# Altium schematic sheet 07 USB2.0_HOST.SchDoc
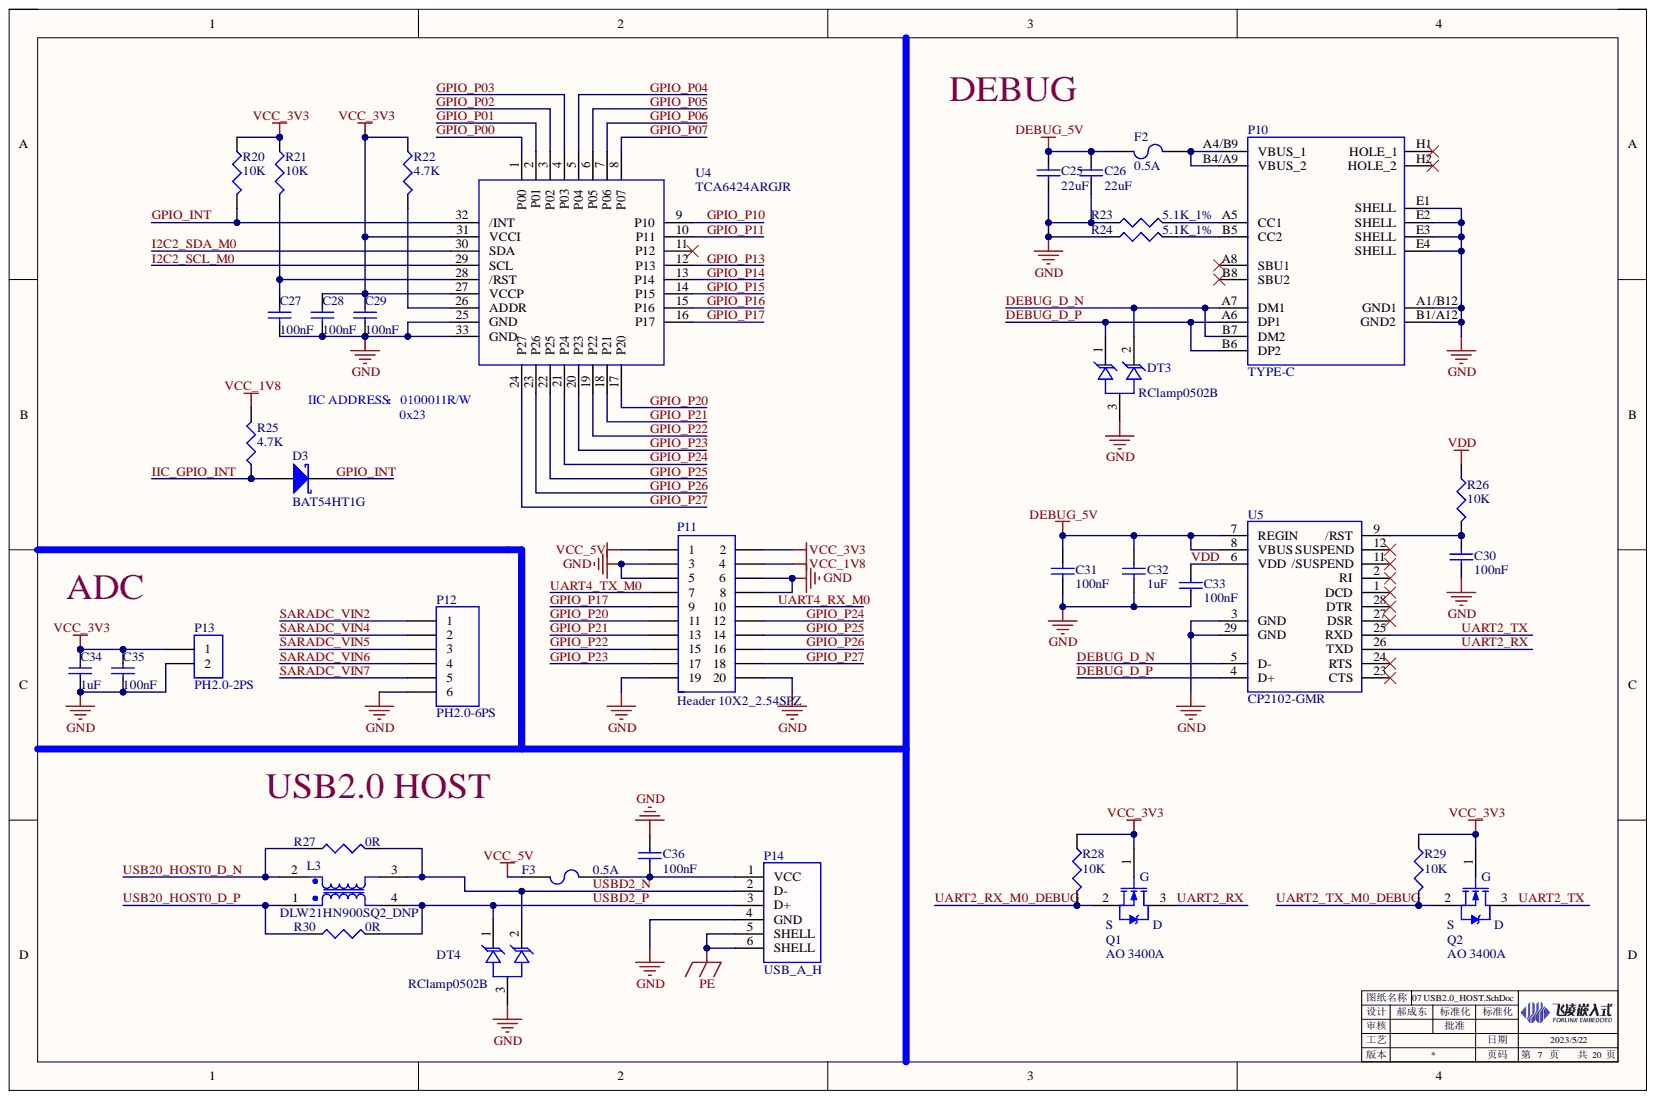
[diagram p0: 07 USB2.0_HOST.SchDoc]

## Parts
C25  22uF  [0603C]
C26  22uF  [0603C]
C27  100nF  [0402C]
C28  100nF  [0402C]
C29  100nF  [0402C]
C30  100nF  [0402C]
C31  100nF  [0402C]
C32  1uF  [0402C]
C33  100nF  [0402C]
C34  1uF  [0402C]
C35  100nF  [0402C]
C36  100nF  [0402C]
D3  BAT54HT1G  [SOD_323]
DT3  RClamp0502B  [SOT_523]
DT4  RClamp0502B  [SOT_523]
F2  0.5A  [1210F]
F3  0.5A  [1210F]
L3  DLW21HN900SQ2_DNP  [L_CHK_2012]
P10  TYPE-C  [USB_TYPE-C_S]
P11  Header 10X2_2.54SPZ  [HEADER2*10_2.54_SPZ]
P12  PH2.0-6PS  [PH2.0_6P_V]
P13  PH2.0-2PS  [PH2.0_2P_V]
P14  USB_A_H  [USB_A_F_H]
Q1  AO 3400A  [SOT_233_W]
Q2  AO 3400A  [SOT_233_W]
R20  10K  [0402R]
R21  10K  [0402R]
R22  4.7K  [0402R]
R23  5.1K_1%  [0402R]
R24  5.1K_1%  [0402R]
R25  4.7K  [0402R]
R26  10K  [0402R]
R27  0R  [0402R]
R28  10K  [0402R]
R29  10K  [0402R]
R30  0R  [0402R]
U4  TCA6424ARGJR  [QFN32P_0.5BSC_5*5_H]
U5  CP2102-GMR  [QFN28P_0.5BSC_5*5_H]

## Nets
DEBUG_5V: C25.2 C26.2 C31.2 C32.2 F2.2 U5.7(REGIN) U5.8(VBUS)
GND: C25.1 C26.1 C27.1 C28.1 C29.1 C30.1 C31.1 C32.1 C33.1 C34.1 C35.1 C36.2 DT3.3 DT4.3 P10.A1/B12(GND1) P10.B1/A12(GND2) P10.E1(SHELL) P10.E2(SHELL) P10.E3(SHELL) P10.E4(SHELL) P11.3 P11.5 P11.6 P11.8 P11.19 P11.20 P12.6 P13.2 P14.4(GND) R23.1 R24.1 U4.25(GND) U4.33(GND) U5.3(GND) U5.29(GND)
PE: P14.5(SHELL) P14.6(SHELL)
VCC_1V8: P11.4 R25.2
VCC_3V3: C28.2 C29.2 C34.2 C35.2 P11.2 P13.1 Q1.1 Q2.1 R20.1 R21.1 R22.1 R28.1 R29.1 U4.27(VCCP) U4.31(VCCI)
VCC_5V: F3.2 P11.1
DEBUG_D_N: DT3.2 P10.A7(DM1) P10.B7(DM2) U5.5(D-)
DEBUG_D_P: DT3.1 P10.A6(DP1) P10.B6(DP2) U5.4(D+)
GPIO_INT: D3.2 R20.2 U4.32(/INT)
GPIO_P00: U4.1(P00)
GPIO_P01: U4.2(P01)
GPIO_P02: U4.3(P02)
GPIO_P03: U4.4(P03)
GPIO_P04: U4.5(P04)
GPIO_P05: U4.6(P05)
GPIO_P06: U4.7(P06)
GPIO_P07: U4.8(P07)
GPIO_P10: U4.9(P10)
GPIO_P11: U4.10(P11)
GPIO_P13: U4.12(P13)
GPIO_P14: U4.13(P14)
GPIO_P15: U4.14(P15)
GPIO_P16: U4.15(P16)
GPIO_P17: P11.9 U4.16(P17)
GPIO_P20: P11.11 U4.17(P20)
GPIO_P21: P11.13 U4.18(P21)
GPIO_P22: P11.15 U4.19(P22)
GPIO_P23: P11.17 U4.20(P23)
GPIO_P24: P11.12 U4.21(P24)
GPIO_P25: P11.14 U4.22(P25)
GPIO_P26: P11.16 U4.23(P26)
GPIO_P27: P11.18 U4.24(P27)
I2C2_SCL_M0: U4.29(SCL)
I2C2_SDA_M0: U4.30(SDA)
IIC_GPIO_INT: D3.1 R25.1
SARADC_VIN2: P12.1
SARADC_VIN4: P12.2
SARADC_VIN5: P12.3
SARADC_VIN6: P12.4
SARADC_VIN7: P12.5
UART2_RX: Q1.3 U5.26(TXD)
UART2_RX_M0_DEBUG: Q1.2 R28.2
UART2_TX: Q2.3 U5.25(RXD)
UART2_TX_M0_DEBUG: Q2.2 R29.2
UART4_RX_M0: P11.10
UART4_TX_M0: P11.7
USB20_HOST0_D_N: L3.2 R27.1
USB20_HOST0_D_P: L3.1 R30.1
USBD2_N: DT4.2 L3.3 P14.2(D-) R27.2
USBD2_P: DT4.1 L3.4 P14.3(D+) R30.2
VDD: C33.2 R26.1 U5.6(VDD)
NetC27_2: C27.2 R21.2 U4.28(/RST)
NetC30_2: C30.2 R26.2 U5.9(/RST)
NetC36_1: C36.1 F3.1 P14.1(VCC)
NetF2_1: F2.1 P10.A4/B9(VBUS_1) P10.B4/A9(VBUS_2)
NetP10_A5: P10.A5(CC1) R23.2
NetP10_B5: P10.B5(CC2) R24.2
NetR22_2: R22.2 U4.26(ADDR)

Not connected: P10 A8(SBU1) B8(SBU2) H1(HOLE_1) H2(HOLE_2); U4 11(P12); U5 1(DCD) 2(RI) 11(/SUSPEND) 12(SUSPEND) 23(CTS) 24(RTS) 27(DSR) 28(DTR)

## Library data of the parts
C25 C26 = 1110203040: Database Table Name: VALID$; 主计量单位名称: 个; 存货名称: 贴装叠层陶瓷电容; 安全库存: 678357; 序号: 675; 旧编码: 010202048; 旧规格型号: 0603 22uF 6.3V ±20％ X5R; 规格型号: 0603,22uF,6.3V,±20％,X5R; Component Kind: Standard; LatestRevisionDate: 17-Jul-2002; LatestRevisionNote: Re-released for DXP Platform.; Library Name: Database_AD Library.DbLib; PackageDescription: Radial Cap, Thru-Hole; 2 Leads; 0.3 in Pin Spacing; PackageReference: RAD-0.3; Published: 8-Jun-2000; Signal Integrity: Cap; Simulation: CAP; Value: 22uF; 存货编码: 1110203040; Publisher: Altium Limited
C27-C29 C35 C36 = 1110202039: Database Table Name: VALID$; 存货名称: 贴装叠层陶瓷电容; 序号: 626; 规格型号: 0402,100nF,25V,±10％,X5R; Component Kind: Standard; LatestRevisionDate: 17-Jul-2002; LatestRevisionNote: Re-released for DXP Platform.; Library Name: Database_AD Library.DbLib; PackageDescription: Radial Cap, Thru-Hole; 2 Leads; 0.3 in Pin Spacing; PackageReference: RAD-0.3; Published: 8-Jun-2000; Signal Integrity: Cap; Simulation: CAP; Value: 100nF; 存货编码: 1110202039; Publisher: Altium Limited
C30 C31 C33 = 1110202001: Database Table Name: VALID$; 主计量单位名称: 个; 存货名称: 贴装叠层陶瓷电容; 安全库存: 2580445; 序号: 570; 旧编码: 010201001; 旧规格型号: 0402 100nF 16V ±10％ X7R; 规格型号: 0402,100nF,16V,±10％,X7R; Component Kind: Standard; LatestRevisionDate: 17-Jul-2002; LatestRevisionNote: Re-released for DXP Platform.; Library Name: Database_AD Library.DbLib; PackageDescription: Radial Cap, Thru-Hole; 2 Leads; 0.3 in Pin Spacing; PackageReference: RAD-0.3; Published: 8-Jun-2000; Signal Integrity: Cap; Simulation: CAP; Value: 100nF; 存货编码: 1110202001; Publisher: Altium Limited
C32 = 1110202002: Database Table Name: VALID$; 主计量单位名称: 个; 存货名称: 贴装叠层陶瓷电容; 安全库存: 499709; 序号: 571; 旧编码: 010201002; 旧规格型号: 0402 1uF 10V ±10％ X5R; 规格型号: 0402,1uF,10V,±10％,X5R; Component Kind: Standard; LatestRevisionDate: 17-Jul-2002; LatestRevisionNote: Re-released for DXP Platform.; Library Name: Database_AD Library.DbLib; PackageDescription: Radial Cap, Thru-Hole; 2 Leads; 0.3 in Pin Spacing; PackageReference: RAD-0.3; Published: 8-Jun-2000; Signal Integrity: Cap; Simulation: CAP; Value: 1uF; 存货编码: 1110202002; Publisher: Altium Limited
C34 = 1110202021: Database Table Name: VALID$; 存货名称: 贴装叠层陶瓷电容; 序号: 600; 规格型号: 0402,1uF,16V,±10％,X5R; Component Kind: Standard; LatestRevisionDate: 17-Jul-2002; LatestRevisionNote: Re-released for DXP Platform.; Library Name: Database_AD Library.DbLib; PackageDescription: Radial Cap, Thru-Hole; 2 Leads; 0.3 in Pin Spacing; PackageReference: RAD-0.3; Published: 8-Jun-2000; Signal Integrity: Cap; Simulation: CAP; Value: 1uF; 存货编码: 1110202021; Publisher: Altium Limited
D3 = 1110501028: Database Table Name: VALID$; 存货名称: 肖特基二极管; 序号: 2525; 规格型号: BAT54HT1G,SOD323; Code_JEDEC: DO-214-AA; Component Kind: Standard; LatestRevisionDate: 17-Jul-2002; LatestRevisionNote: Re-released for DXP Platform.; Library Name: Database_AD Library.DbLib; PackageDescription: DO-214-AA/SMB; 2 C-Bend Leads; Body 5.3 x 3.6 mm, inc. leads (LxW); PackageReference: SMB; Published: 8-Jun-2000; Signal Integrity: D Schottky; Simulation: SKYDIODE; Value: BAT54HT1G; 存货编码: 1110501028; Publisher: Altium Limited
DT3 DT4 = 1110503016: Database Table Name: VALID$; 主计量单位名称: 只; 存货名称: TVS管; 安全库存: 2084.00; 序号: 2598; 旧编码: 010603010; 规格型号: RC1amp0502B SOT-523; Component Kind: Standard; Library Name: Database_AD Library.DbLib; Value: RClamp0502B; 启用日期: 2013/1/1; 存货编码: 1110503016
F2 F3 = 1110305006: Database Table Name: VALID$; 主计量单位名称: 个; 存货名称: 自恢复保险; 安全库存: 4426.00; 序号: 3432; 旧编码: 010803008; 规格型号: 1210，0.5A; Component Kind: Standard; LatestRevisionDate: 17-Jul-2002; LatestRevisionNote: Re-released for DXP Platform.; Library Name: Database_AD Library.DbLib; PackageDescription: Thru-Hole; 2 Leads; PackageReference: PIN-W2/E2.8; Published: 8-Jun-2000; Simulation: FUSE; Value: 0.5A; 启用日期: 2013/1/1; 存货编码: 1110305006; Publisher: Altium Limited
L3 = 1110303003: Database Table Name: VALID$; 存货名称: 贴装共模电感; 序号: 1015; 规格型号: 2012(0805),90 Ohm@100MHz,330mA,LW21HN900SQ2（替代DLW21SN900SQ2B/L）; Component Kind: Standard; Library Name: Database_AD Library.DbLib; Value: DLW21HN900SQ2_DNP; 存货编码: 1110303003
P10 = 1120102031: Database Table Name: VALID$; 存货名称: 贴装USB座; 规格型号: USB2.0,type-c,母座,单层,横卧,16pin,（两脚为插装）; Component Kind: Standard; Library Name: Database_AD Library.DbLib; Value: TYPE-C; 存货编码: 1120102031
P11 = 1120201243: Database Table Name: VALID$; 存货名称: 插装插针; 规格型号: 双排,2*10P,2.54mm,S; Component Kind: Standard; LatestRevisionDate: 17-Jul-2002; LatestRevisionNote: Re-released for DXP Platform.; Library Name: Database_AD Library.DbLib; Signal Integrity: Connector; Value: Header 10X2_2.54SPZ; 存货编码: 1120201243; Publisher: Altium Limited
P12 = 1120201009: Database Table Name: VALID$; 存货名称: 插装连接器; 序号: 1263; 规格型号: PH,公头,6P,2.0mm,S; Component Kind: Standard; LatestRevisionDate: 17-Jul-2002; LatestRevisionNote: Re-released for DXP Platform.; Library Name: Database_AD Library.DbLib; Signal Integrity: Connector; Value: PH2.0-6PS; 存货编码: 1120201009; Publisher: Altium Limited
P13 = 1120201007: Database Table Name: VALID$; 存货名称: 插装连接器; 序号: 1261; 规格型号: PH,公头,2P,2.0mm,S; Component Kind: Standard; LatestRevisionDate: 17-Jul-2002; LatestRevisionNote: Re-released for DXP Platform.; Library Name: Database_AD Library.DbLib; Signal Integrity: Connector; Value: PH2.0-2PS; 存货编码: 1120201007; Publisher: Altium Limited
P14 = 1120202015: Database Table Name: VALID$; 存货名称: 插装USB座; 序号: 1288; 规格型号: A型,母座,单层,横卧; Component Kind: Standard; Library Name: Database_AD Library.DbLib; Value: USB_A_H; 存货编码: 1120202015
Q1 Q2 = 1110505027: Database Table Name: VALID$; Note: Pin 2 is electrically connected to pin 4.; 存货名称: 场效应管; 描述栏信息: 替换1110505011; 规格型号: AO3400A,SOT23,N沟道; Component Kind: Standard; DatasheetVersion: PD-9.814; LatestRevisionDate: 17-Jul-2002; LatestRevisionNote: Re-released for DXP Platform.; Library Name: Database_AD Library.DbLib; PackageDescription: TO, Thru-Hole, Vertical, Heatsink Mounted; 3 In-Line Leads; Pitch 2.54 mm; PackageReference: TO-220AB; PackageVersion: Feb-1997; Published: 8-Jun-2000; Simulation: IRF1010; VALUE: AO 3400A; 存货编码: 1110505027; Publisher: Altium Limited
R20 R21 R26 R28 R29 = 1110102007: Database Table Name: VALID$; 存货名称: 贴装电阻; 序号: 17; 规格型号: 0402,10K,±5％; Component Kind: Standard; Library Name: Database_AD Library.DbLib; Signal Integrity: Res; Simulation: RESISTOR; Value: 10K; 存货编码: 1110102007
R22 R25 = 1110102003: Database Table Name: VALID$; 存货名称: 贴装电阻; 序号: 12; 规格型号: 0402,4.7K,±5％; Component Kind: Standard; Library Name: Database_AD Library.DbLib; Signal Integrity: Res; Simulation: RESISTOR; Value: 4.7K; 存货编码: 1110102003
R23 R24 = 1110102136: Database Table Name: VALID$; 存货名称: 贴装电阻; 规格型号: 0402,5.1K,±1％; Component Kind: Standard; Library Name: Database_AD Library.DbLib; Signal Integrity: Res; Simulation: RESISTOR; Value: 5.1K_1%; 存货编码: 1110102136
R27 R30 = 1110102001: Database Table Name: VALID$; 存货名称: 贴装电阻; 序号: 10; 规格型号: 0402,0Ω,±5％; Component Kind: Standard; Library Name: Database_AD Library.DbLib; Signal Integrity: Res; Simulation: RESISTOR; Value: 0R; 存货编码: 1110102001
U4 = 1110405102: Database Table Name: VALID$; 存货名称: 常规IC; 序号: 2208; 规格型号: TCA6424ARGJR,QFN32; Component Kind: Standard; Library Name: Database_AD Library.DbLib; Value: TCA6424ARGJR; 存货编码: 1110405102
U5 = 1110405210: Database Table Name: VALID$; 存货名称: 常规IC; 序号: 2430; 规格型号: CP2102-GMR,QFN28; Component Kind: Standard; Library Name: Database_AD Library.DbLib; Value: CP2102-GMR; 存货编码: 1110405210
